# Altium schematic sheet MCU_POWER.SchDoc(MCU_POWER)
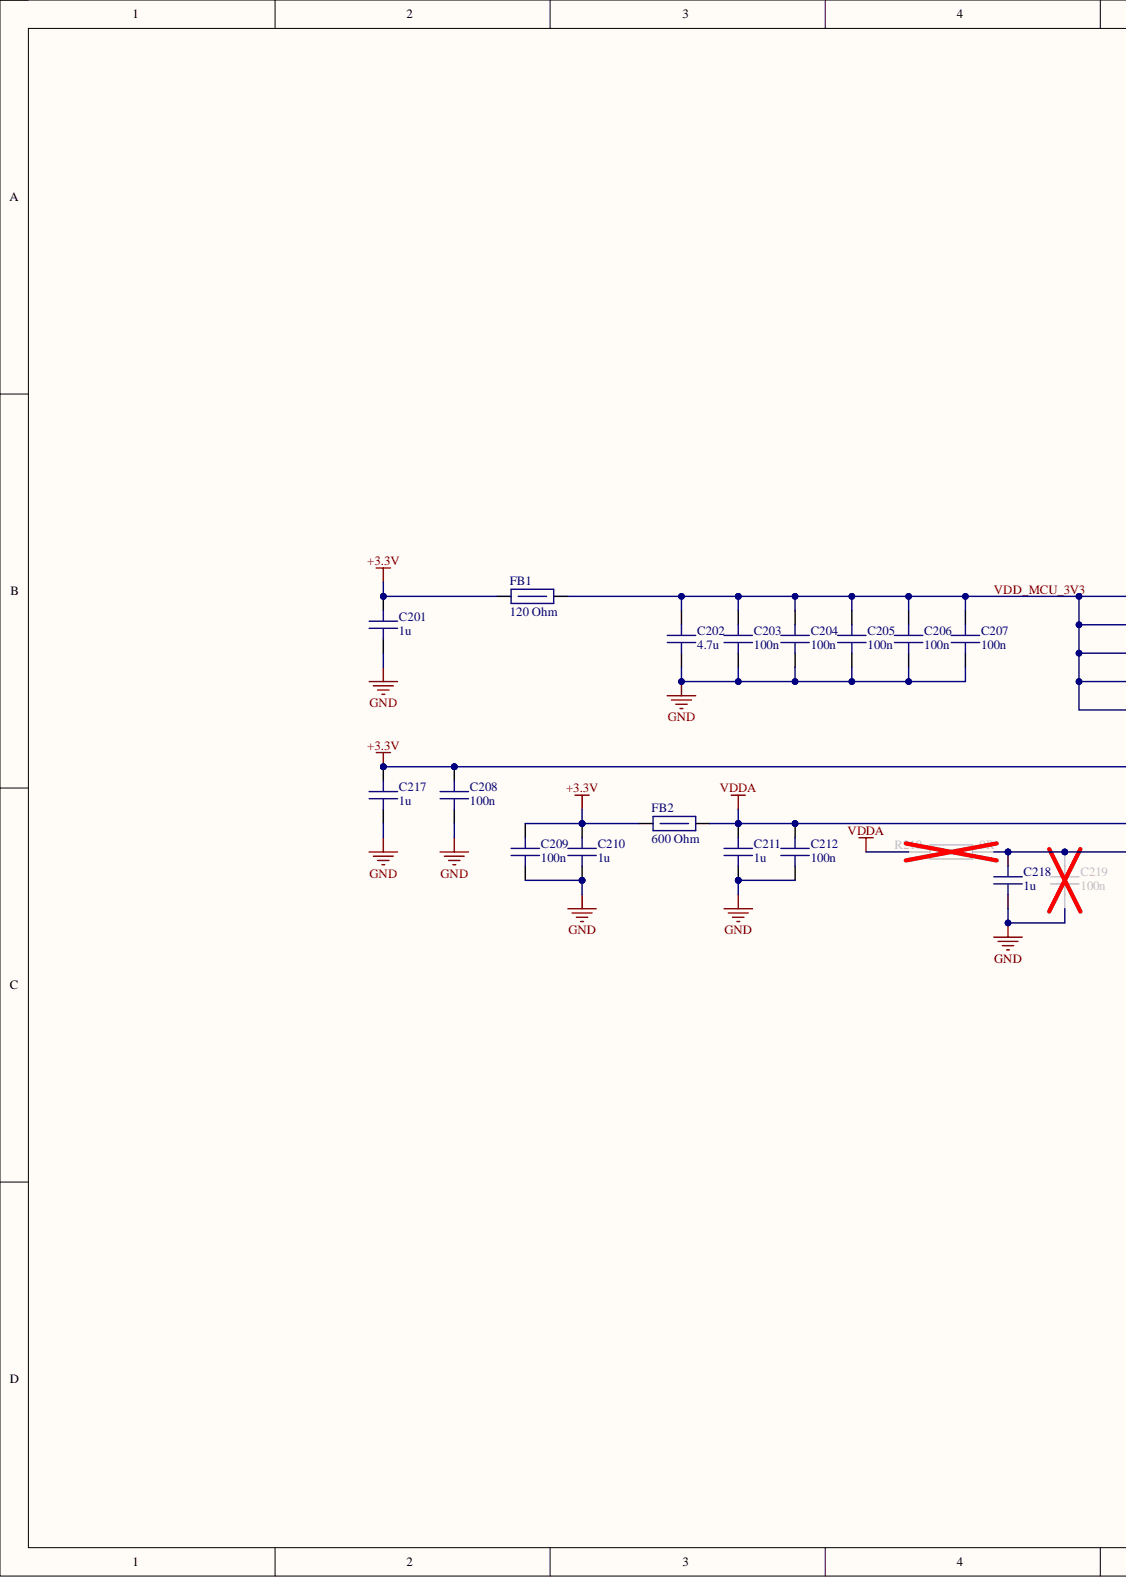
[diagram p0: MCU_POWER.SchDoc, left]
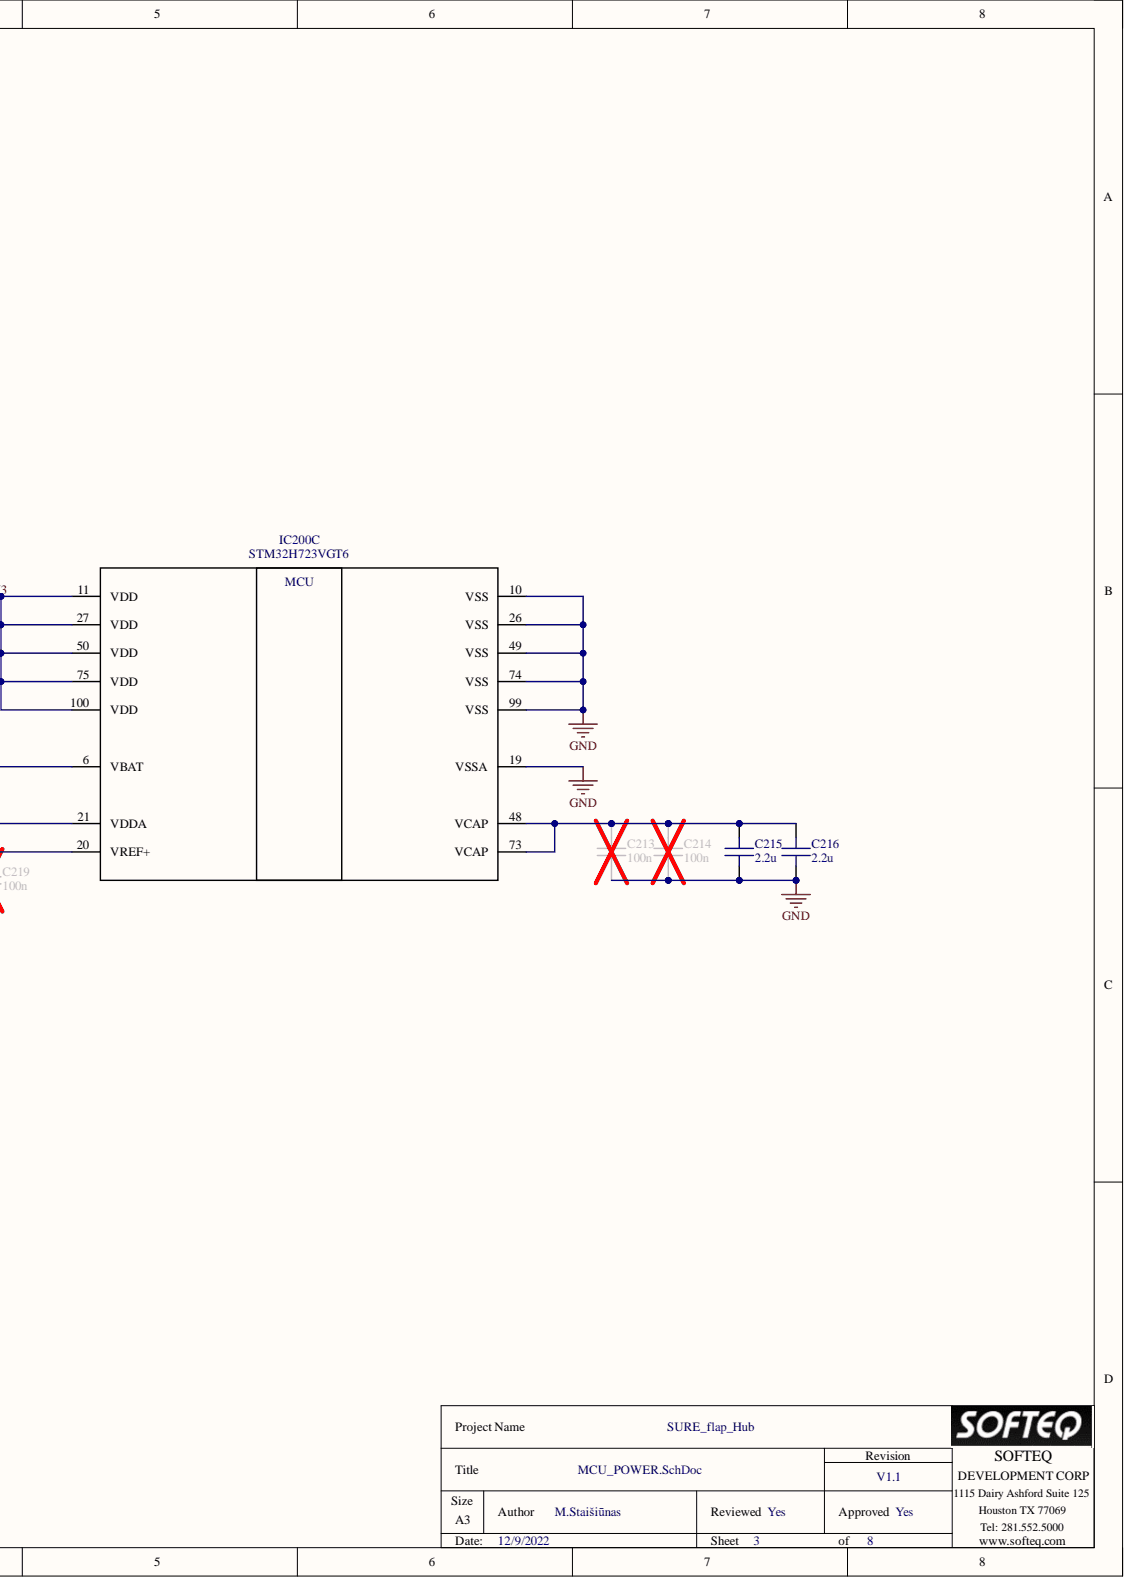
[diagram p1: MCU_POWER.SchDoc, right]

## Parts
C201  value 1u  [C_0603]  (hidden comment: CL10A105KO8NNNC)
C202  value 4.7u  [C_0603]  (hidden comment: C0603C475K8PACTU)
C203  value 100n  [C_0402]  (hidden comment: GRM155R62A104KE14D)
C204  value 100n  [C_0402]  (hidden comment: GRM155R62A104KE14D)
C205  value 100n  [C_0402]  (hidden comment: GRM155R62A104KE14D)
C206  value 100n  [C_0402]  (hidden comment: GRM155R62A104KE14D)
C207  value 100n  [C_0402]  (hidden comment: GRM155R62A104KE14D)
C208  value 100n  [C_0402]  (hidden comment: CL05B104KO5NNNC)
C209  value 100n  [C_0402]  (hidden comment: CL05B104KO5NNNC)
C210  value 1u  [C_0603]  (hidden comment: CL10A105KO8NNNC)
C211  value 1u  [C_0603]  (hidden comment: CL10A105KO8NNNC)
C212  value 100n  [C_0402]  (hidden comment: CL05B104KO5NNNC)
C213  value 100n  [C_0402]  (hidden comment: CL05B104KO5NNNC)
C214  value 100n  [C_0402]  (hidden comment: CL05B104KO5NNNC)
C215  value 2.2u  [C_0402]  (hidden comment: CC0402KRX5R6BB225)
C216  value 2.2u  [C_0402]  (hidden comment: CC0402KRX5R6BB225)
C217  value 1u  [C_0603]  (hidden comment: CL10A105KO8NNNC)
C218  value 1u  [C_0603]  (hidden comment: CL10A105KO8NNNC)
C219  value 100n  [C_0402]  (hidden comment: CL05B104KO5NNNC)
FB1  value 120 Ohm  [IND_0603]  (hidden comment: BLM18PG121SN1D)
FB2  value 600 Ohm  [IND_0402]  (hidden comment: BLM15PX601SN1D)
IC200  STM32H723VGT6  [QFP-100[25x25]_0.30_0.50_14X14X1.6]  (part C)
R210  value 0R  [R_0402]  (hidden comment: RC0402FR-070RL)

## Nets
+3.3V: C201.2 C208.2 C209.2 C210.2 C217.2 FB1.1 FB2.1 IC200.6(VBAT)
GND: C201.1 C202.1 C203.1 C204.1 C205.1 C206.1 C207.1 C208.1 C209.1 C210.1 C211.1 C212.1 C213.1 C214.1 C215.1 C216.1 C217.1 C218.1 C219.1 IC200.10(VSS) IC200.19(VSSA) IC200.26(VSS) IC200.49(VSS) IC200.74(VSS) IC200.99(VSS)
VDDA: C211.2 C212.2 FB2.2 IC200.21(VDDA) R210.1
VDD_MCU_3V3: C202.2 C203.2 C204.2 C205.2 C206.2 C207.2 FB1.2 IC200.11(VDD) IC200.27(VDD) IC200.50(VDD) IC200.75(VDD) IC200.100(VDD)
NetC213_2: C213.2 C214.2 C215.2 C216.2 IC200.48(VCAP) IC200.73(VCAP)
NetC218_2: C218.2 C219.2 IC200.20(VREF+) R210.2

## Library data of the parts
C201 C210 C211 C217 C218 = CL10A105KO8NNNC: Capacitor, 1uF, 16V, X5R, 10%, -55/+85, 0603; Manufacturer: Samsung; Database Table Name: Capacitors; Component Kind: Standard; ComponentHeight: 0.9mm; Library Name: Library.dblib; Mounted: Yes; PartNumber: CL10A105KO8NNNC; SMD: Yes; Socket: No; Author: KAI
C202 = C0603C475K8PACTU: Capacitor, 4.7uF, 10%, 10V, X5R, -55/+85, 0603; Manufacturer: Kemet; Database Table Name: Capacitors; Component Kind: Standard; ComponentHeight: 0.9mm; Library Name: Library.dblib; Mounted: Yes; PartNumber: C0603C475K8PACTU; SMD: Yes; Author: KMA
C203-C207 = GRM155R62A104KE14D: Capacitor, 100nF, 10%, 100V, X5R, -55/+85, 0402; Manufacturer: Murata; Database Table Name: Capacitors; Component Kind: Standard; ComponentHeight: 0.55mm; Library Name: Library.DbLib; Mounted: Yes; PartNumber: GRM155R62A104KE14D; SMD: Yes; Author: KAI
C208 C209 C212-C214 C219 = CL05B104KO5NNNC: Capacitor, 100nF, 16V, X7R, 10%, -55/+125, 0402; Manufacturer: Samsung; Database Table Name: Capacitors; Component Kind: Standard; ComponentHeight: 0.55mm; Library Name: Library.dblib; Mounted: Yes; PartNumber: CL05B104KO5NNNC; SMD: Yes; Socket: No; Author: KAI
C215 C216 = CC0402KRX5R6BB225: Capacitor, 2.2uF, 10V, X5R, 10%, -55/+85, 0402; Manufacturer: Yageo; Database Table Name: Capacitors; Component Kind: Standard; ComponentHeight: 0.5mm; Library Name: Library.dblib; Mounted: Yes; PartNumber: CC0402KRX5R6BB225; SMD: Yes; Author: KAI
FB1 = BLM18PG121SN1D: Ferrite Bead, 120Ohm/100MHz, 25%, 2A, -55/+125, 0603; Manufacturer: Murata; Database Table Name: Inductor_Transformer; Component Kind: Standard; ComponentHeight: 0.95mm; Library Name: Library.dblib; Mounted: Yes; PartNumber: BLM18PG121SN1D; Power: 2A; SMD: Yes; Tolerance: ±25%; Author: KAI
FB2 = BLM15PX601SN1D: Ferrite Bead, 600Ohm/100MHz, 25%, 900mA, -55/+125, 0402; Manufacturer: Murata; Database Table Name: Inductor_Transformer; Power: 0.9A; Component Kind: Standard; ComponentHeight: 0.55mm; Library Name: Library.dblib; Mounted: Yes; PartNumber: BLM15PX601SN1D; SMD: Yes; Author: KAI
IC200 = STM32H723VGT6: IC, MCU, 32-bit, Single Core, ARM Cortex-M7, 550MHz, 1MB Flash, -40/+85, LQFP-100; Manufacturer: ST; Database Table Name: IC Digital; Component Kind: Standard; ComponentHeight: 1.6mm; Library Name: Library.dblib; Mounted: Yes; PartNumber: STM32H723VGT6; SMD: Yes; Author: DZ
R210 = RC0402FR-070RL: Resistor, 0, 1%, 0.063W, -55/+155, 0402; Manufacturer: Yageo; Database Table Name: Resistors; Component Kind: Standard; ComponentHeight: 0.4mm; Library Name: Library.dblib; Mounted: Yes; PartNumber: RC0402FR-070RL; SMD: Yes; Socket: No; Author: KAI
